# Altium schematic sheet 10_FPGA_E.SchDoc
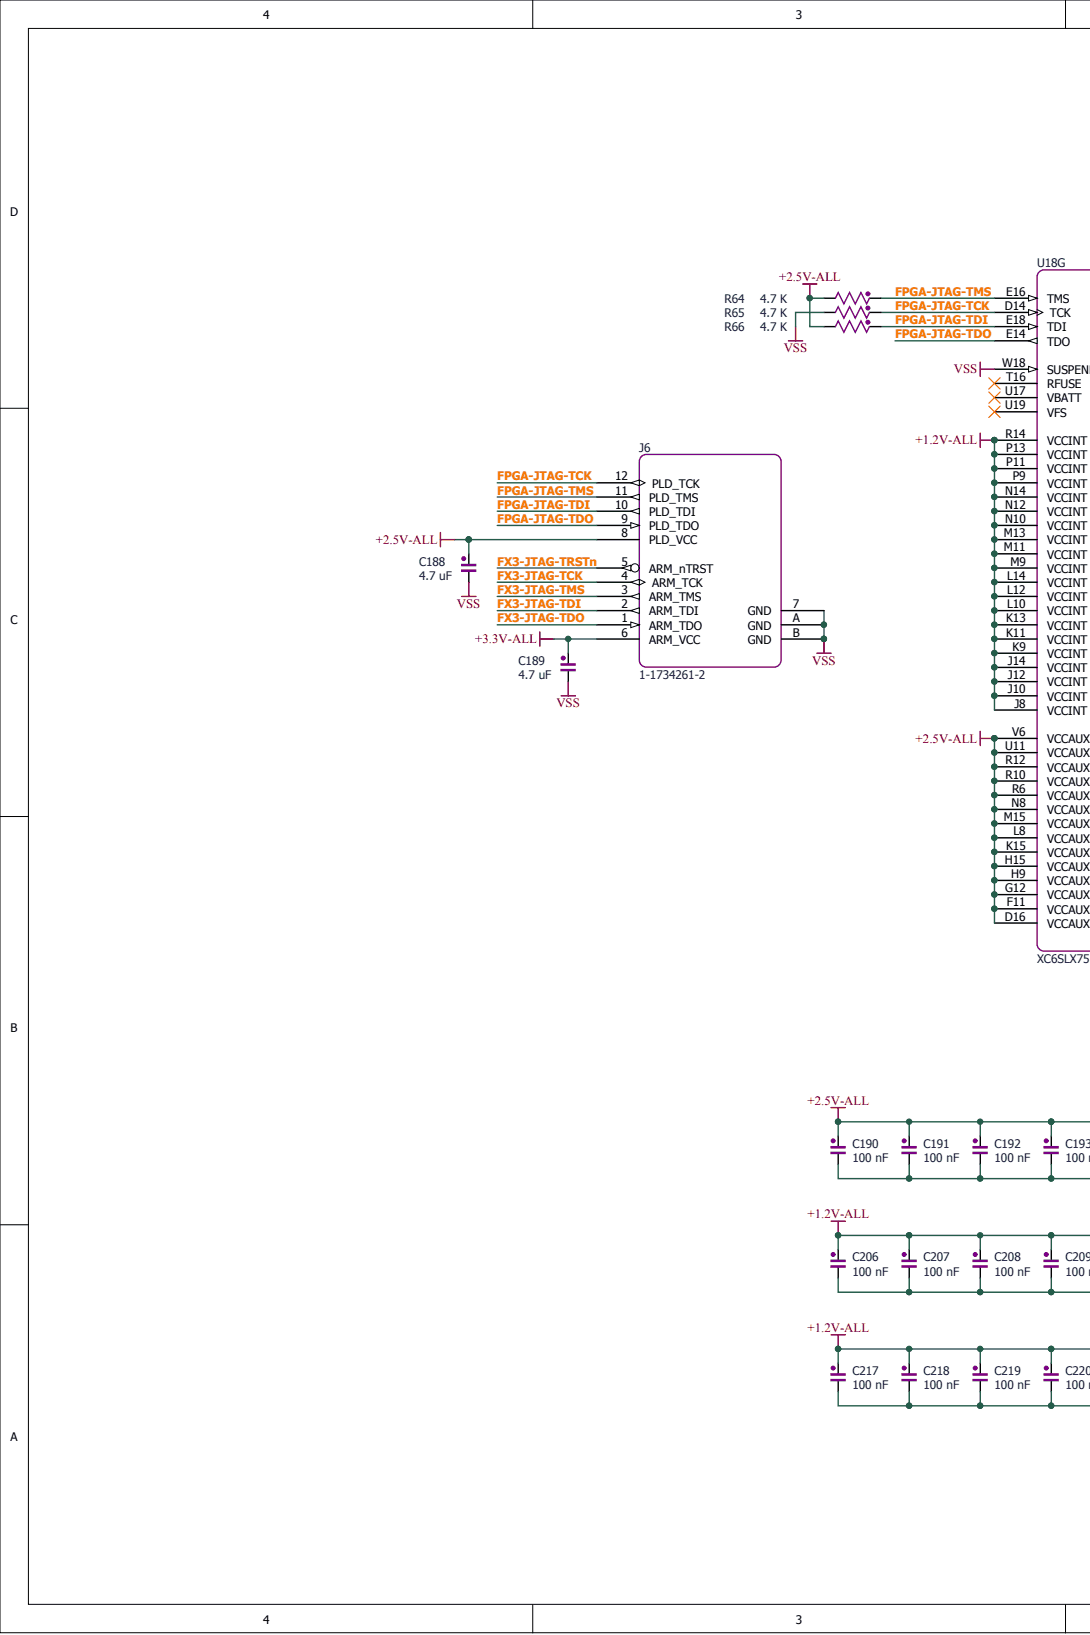
[diagram p0: 10_FPGA_E.SchDoc, left]
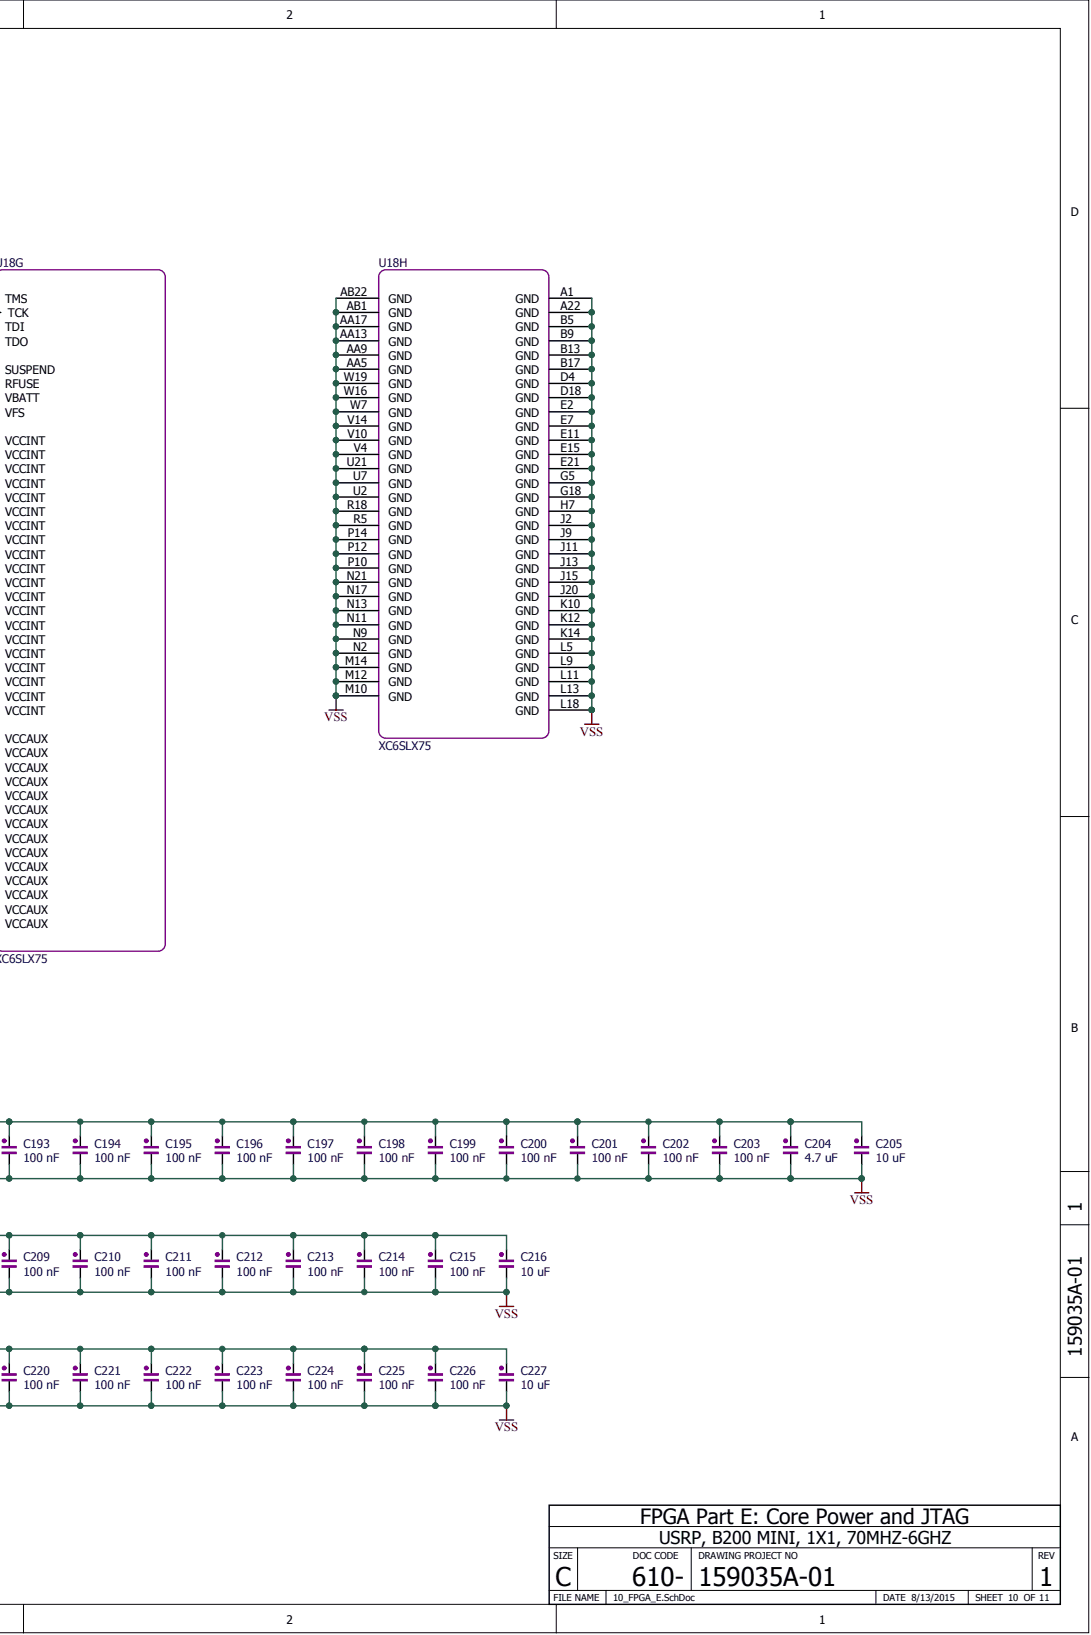
[diagram p1: 10_FPGA_E.SchDoc, right]

## Parts
C188  4.7 uF  [0402-CAP]
C189  4.7 uF  [0402-CAP]
C190  100 nF  [0201-CAP]
C191  100 nF  [0201-CAP]
C192  100 nF  [0201-CAP]
C193  100 nF  [0201-CAP]
C194  100 nF  [0201-CAP]
C195  100 nF  [0201-CAP]
C196  100 nF  [0201-CAP]
C197  100 nF  [0201-CAP]
C198  100 nF  [0201-CAP]
C199  100 nF  [0201-CAP]
C200  100 nF  [0201-CAP]
C201  100 nF  [0201-CAP]
C202  100 nF  [0201-CAP]
C203  100 nF  [0201-CAP]
C204  4.7 uF  [0402-CAP]
C205  10 uF  [0402-CAP]
C206  100 nF  [0201-CAP]
C207  100 nF  [0201-CAP]
C208  100 nF  [0201-CAP]
C209  100 nF  [0201-CAP]
C210  100 nF  [0201-CAP]
C211  100 nF  [0201-CAP]
C212  100 nF  [0201-CAP]
C213  100 nF  [0201-CAP]
C214  100 nF  [0201-CAP]
C215  100 nF  [0201-CAP]
C216  10 uF  [0402-CAP]
C217  100 nF  [0201-CAP]
C218  100 nF  [0201-CAP]
C219  100 nF  [0201-CAP]
C220  100 nF  [0201-CAP]
C221  100 nF  [0201-CAP]
C222  100 nF  [0201-CAP]
C223  100 nF  [0201-CAP]
C224  100 nF  [0201-CAP]
C225  100 nF  [0201-CAP]
C226  100 nF  [0201-CAP]
C227  10 uF  [0402-CAP]
J6  1-1734261-2  [1-1734261-2]
R64  4.7 K  [0201-RES]
R65  4.7 K  [0201-RES]
R66  4.7 K  [0201-RES]
U18  XC6SLX75  [CS(G)484-XC6SLX75]  (parts G,H)

## Nets
+1.2V-ALL: C206.2 C207.2 C208.2 C209.2 C210.2 C211.2 C212.2 C213.2 C214.2 C215.2 C216.2 C217.2 C218.2 C219.2 C220.2 C221.2 C222.2 C223.2 C224.2 C225.2 C226.2 C227.2 U18.J8(VCCINT) U18.J10(VCCINT) U18.J12(VCCINT) U18.J14(VCCINT) U18.K9(VCCINT) U18.K11(VCCINT) U18.K13(VCCINT) U18.L10(VCCINT) U18.L12(VCCINT) U18.L14(VCCINT) U18.M9(VCCINT) U18.M11(VCCINT) U18.M13(VCCINT) U18.N10(VCCINT) U18.N12(VCCINT) U18.N14(VCCINT) U18.P9(VCCINT) U18.P11(VCCINT) U18.P13(VCCINT) U18.R14(VCCINT)
+2.5V-ALL: C188.2 C190.2 C191.2 C192.2 C193.2 C194.2 C195.2 C196.2 C197.2 C198.2 C199.2 C200.2 C201.2 C202.2 C203.2 C204.2 C205.2 J6.8(PLD_VCC) R64.1 R66.1 U18.D16(VCCAUX) U18.F11(VCCAUX) U18.G12(VCCAUX) U18.H9(VCCAUX) U18.H15(VCCAUX) U18.K15(VCCAUX) U18.L8(VCCAUX) U18.M15(VCCAUX) U18.N8(VCCAUX) U18.R6(VCCAUX) U18.R10(VCCAUX) U18.R12(VCCAUX) U18.U11(VCCAUX) U18.V6(VCCAUX)
+3.3V-ALL: C189.2 J6.6(ARM_VCC)
VSS: C188.1 C189.1 C190.1 C191.1 C192.1 C193.1 C194.1 C195.1 C196.1 C197.1 C198.1 C199.1 C200.1 C201.1 C202.1 C203.1 C204.1 C205.1 C206.1 C207.1 C208.1 C209.1 C210.1 C211.1 C212.1 C213.1 C214.1 C215.1 C216.1 C217.1 C218.1 C219.1 C220.1 C221.1 C222.1 C223.1 C224.1 C225.1 C226.1 C227.1 J6.7(GND) J6.A(GND) J6.B(GND) R65.1 U18.A1(GND) U18.A22(GND) U18.AA5(GND) U18.AA9(GND) U18.AA13(GND) U18.AA17(GND) U18.AB1(GND) U18.AB22(GND) U18.B5(GND) U18.B9(GND) U18.B13(GND) U18.B17(GND) U18.D4(GND) U18.D18(GND) U18.E2(GND) U18.E7(GND) U18.E11(GND) U18.E15(GND) U18.E21(GND) U18.G5(GND) U18.G18(GND) U18.H7(GND) U18.J2(GND) U18.J9(GND) U18.J11(GND) U18.J13(GND) U18.J15(GND) U18.J20(GND) U18.K10(GND) U18.K12(GND) U18.K14(GND) U18.L5(GND) U18.L9(GND) U18.L11(GND) U18.L13(GND) U18.L18(GND) U18.M10(GND) U18.M12(GND) U18.M14(GND) U18.N2(GND) U18.N9(GND) U18.N11(GND) U18.N13(GND) U18.N17(GND) U18.N21(GND) U18.P10(GND) U18.P12(GND) U18.P14(GND) U18.R5(GND) U18.R18(GND) U18.U2(GND) U18.U7(GND) U18.U21(GND) U18.V4(GND) U18.V10(GND) U18.V14(GND) U18.W7(GND) U18.W16(GND) U18.W18(SUSPEND) U18.W19(GND)
FPGA-JTAG-TCK: J6.12(PLD_TCK) R65.2 U18.D14(TCK)
FPGA-JTAG-TDI: J6.10(PLD_TDI) R66.2 U18.E18(TDI)
FPGA-JTAG-TDO: J6.9(PLD_TDO) U18.E14(TDO)
FPGA-JTAG-TMS: J6.11(PLD_TMS) R64.2 U18.E16(TMS)
FX3-JTAG-TCK: J6.4(ARM_TCK)
FX3-JTAG-TDI: J6.2(ARM_TDI)
FX3-JTAG-TDO: J6.1(ARM_TDO)
FX3-JTAG-TMS: J6.3(ARM_TMS)
FX3-JTAG-TRSTN: J6.5(ARM_nTRST)

Not connected: U18 T16(RFUSE) U17(VBATT) U19(VFS)

## Library data of the parts
C188 C189 C204 = CAP: CAP, 4.7uF, X5R, 6.3V, ±20%, 0402, PbF; PartNumber: GRM155R60J475ME47; Designer: shyul; PCBMarker: Capacitor; PinCountHole: 0; PinCountSMD: 2; Vendor: muRata; Component Kind: Standard; SerialNumber: 0; Value: 4.7 uF; Version: 1.0; VersionDate: 04-27-2011; Library Name: Basc_1_Passive.IntLib; Publisher: Cowin
C190-C203 C206-C215 C217-C226 = CAP: CAP,SM,0.1UF,16V,10%,CER,X5R,0201,H=.014IN; PartNumber: GRM033R61C104KE14D; Designer: shyul; PCBMarker: Capacitor; PinCountHole: 0; PinCountSMD: 2; SerialNumber: 717062-01; Vendor: MURATA; Component Kind: Standard; Value: 100 nF; Version: 1.0; VersionDate: 04-27-2011; Library Name: Basc_1_Passive.IntLib; Publisher: Cowin
C205 C216 C227 = CAP: CAP, 10uF, X5R, 6.3V, ±20%, 0402, PbF; PartNumber: C0402X5R6R3-106MNP; Designer: shyul; PCBMarker: Capacitor; PinCountHole: 0; PinCountSMD: 2; Vendor: VENKEL; Component Kind: Standard; SerialNumber: 0; Value: 10 uF; Version: 1.0; VersionDate: 04-27-2011; Library Name: Basc_1_Passive.IntLib; Publisher: Cowin
J6 = HPI_JTAG: JTAG Connector; Designer: shyul; PinCountHole: 0; PinCountSMD: 14; Vendor: Tyco; Component Kind: Standard; PartNumber: 1-1734261-2; PCBMarker: JTAG; SerialNumber: 0; Value: 1-1734261-2; Version: 1.0; VersionDate: 11-07-2014; Library Name: Argon.SchLib; Publisher: Shyu Lee
R64-R66 = RES: RES, 4.7K, ±5%, 1/20W, 0201, PbF; PartNumber: CR0201-20W-472JT; Designer: shyul; PCBMarker: Resistor; PinCountHole: 0; PinCountSMD: 2; Vendor: VENKEL; Component Kind: Standard; SerialNumber: 0; Value: 4.7 K; Version: 1.0; VersionDate: 04-27-2011; Library Name: Basc_1_Passive.IntLib; Publisher: Cowin
U18 = XC6SLX75: Xilinx Spartan-6 FPGA; Designer: shyul; PinCountSMD: 484; SerialNumber: 0; Component Kind: Standard; PartNumber: XC6SLX75; Value: XC6SLX75; Vendor: Xilinx; Version: 1.0; VersionDate: 09-18-2014; Library Name: Argon.SchLib; Publisher: Shyu Lee
